# Altium schematic sheet Sheet2_V2.SchDoc(Sheet2_V2)
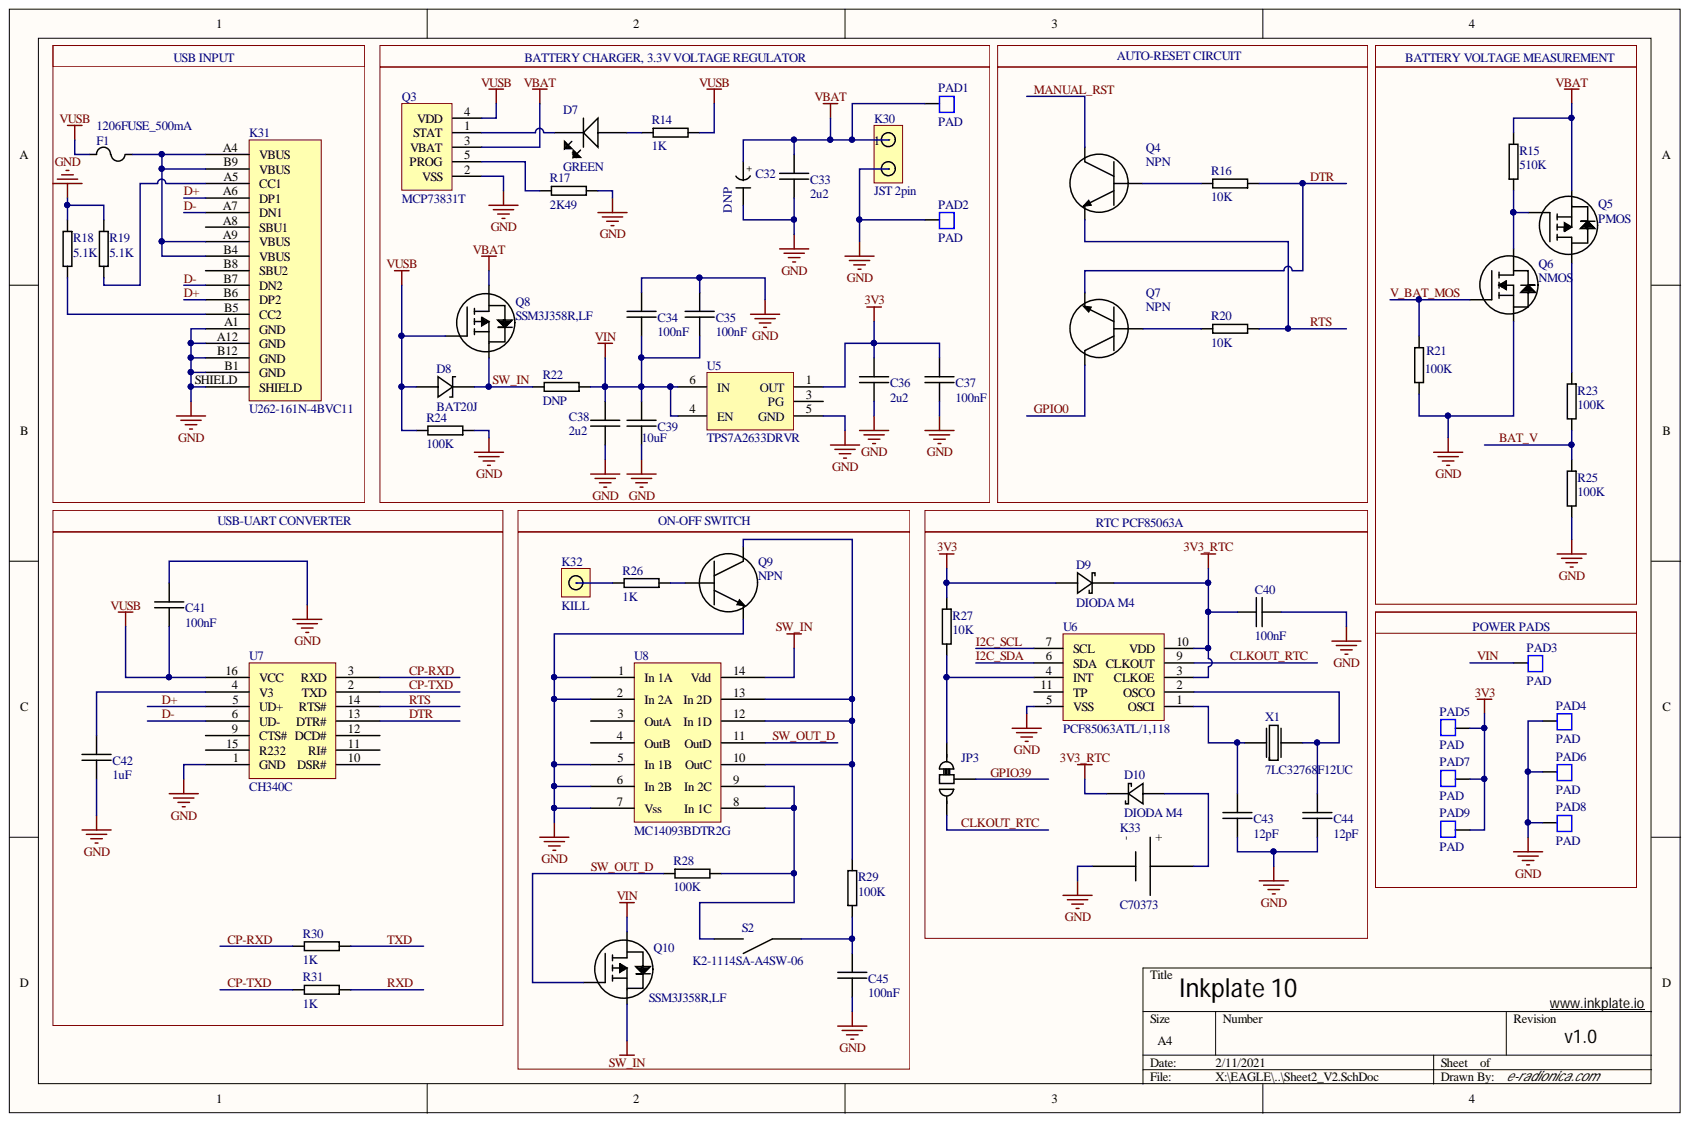
[diagram p0: Sheet2_V2.SchDoc]

## Parts
C32  DNP  [2917C]
C33  2u2  [0603C]
C34  100nF  [0603C]
C35  100nF  [0603C]
C36  2u2  [0603C]
C37  100nF  [0603C]
C38  2u2  [0603C]
C39  10uF  [1206C]
C40  100nF  [0603C]
C41  100nF  [0603C]
C42  1uF  [0603C]
C43  12pF  [0603C]
C44  12pF  [0603C]
C45  100nF  [0603C]
D7  GREEN  [0603LED]
D8  BAT20J  [SOD-323]
D9  DIODA M4  [DIODA SMA]
D10  DIODA M4  [DIODA SMA]
F1  1206FUSE_500mA  [1206FUSE]
JP3  2/3 pads connected  [SMD_JUMPER 2/3 pads]  (value not drawn)
K30  JST 2pin  [JST 2PIN SMD]
K31  U262-161N-4BVC11  [U262-161N-4BVC11]
K32  KILL  [HEADER MALE 1x1]
K33  C70373  [C70373]
PAD1  PAD  [PAD4x4]
PAD2  PAD  [PAD4x4]
PAD3  PAD  [PAD4x4]
PAD4  PAD  [PAD4x4]
PAD5  PAD  [PAD4x4]
PAD6  PAD  [PAD4x4]
PAD7  PAD  [PAD4x4]
PAD8  PAD  [PAD4x4]
PAD9  PAD  [PAD4x4]
Q3  MCP73831T  [SOT-23-5]
Q4  NPN  [SOT-23-3]
Q5  PMOS  [SOT-23-3]
Q6  NMOS  [SOT-23-3]
Q7  NPN  [SOT-23-3]
Q8  SSM3J358R,LF  [SOT-23-3]
Q9  NPN  [SOT-23-3]
Q10  SSM3J358R,LF  [SOT-23-3]
R14  1K  [0603R]
R15  510K  [0603R]
R16  10K  [0603R]
R17  2K49  [0603R]
R18  5.1K  [0603R]
R19  5.1K  [0603R]
R20  10K  [0603R]
R21  100K  [0603R]
R22  DNP  [0603R]
R23  100K  [0603R]
R24  100K  [0603R]
R25  100K  [0603R]
R26  1K  [0603R]
R27  10K  [0603R]
R28  100K  [0603R]
R29  100K  [0603R]
R30  1K  [0603R]
R31  1K  [0603R]
S2  K2-1114SA-A4SW-06  [K2-1114SA-A4SW-06]
U5  TPS7A2633DRVR  [TPS7A2633DRVR]
U6  PCF85063ATL/1,118  [DFN-10]
U7  CH340C  [SOP-16]
U8  MC14093BDTR2G  [TSSOP14_MC14093B]
X1  7LC32768F12UC  [3215Crystal]

## Nets
3V3: C36.2 C37.2 D9.1 PAD5.1 PAD7.1 PAD9.1 R27.2 U5.1(OUT)
3V3_RTC: C40.1 D9.2 D10.2 U6.3(CLKOE) U6.10(VDD)
GND: C32.2 C33.1 C34.2 C35.2 C36.1 C37.1 C38.1 C39.1 C40.2 C41.2 C42.1 C43.1 C44.1 C45.1 K30.2 K31.A1(GND) K31.A12(GND) K31.B1(GND) K31.B12(GND) K31.SHIELD K33.1 PAD2.1 PAD4.1 PAD6.1 PAD8.1 Q3.2(VSS) Q6.2 Q9.2 R17.1 R18.2 R19.2 R21.1 R24.2 R25.1 U5.5(GND) U6.5(VSS) U7.1(GND) U8.1(In_1A) U8.2(In_2A) U8.5(In_1B) U8.6(In_2B) U8.7(Vss)
VBAT: C32.1 C33.2 K30.1 PAD1.1 Q3.3(VBAT) Q5.2 Q8.3 R15.1
VUSB: C41.1 D8.1 F1.1 Q3.4(VDD) Q8.1 R14.1 R24.1 U7.16(VCC)
BAT_V: R23.1 R25.2
CLKOUT_RTC: JP3.1 U6.9(CLKOUT)
CP-RXD: R30.1 U7.3(RXD)
CP-TXD: R31.1 U7.2(TXD)
D+: K31.A6(DP1) K31.B6(DP2) U7.5(UD+)
D-: K31.A7(DN1) K31.B7(DN2) U7.6(UD-)
DTR: Q7.2 R16.2 U7.13(DTR#)
GPIO0: Q7.3
GPIO39: JP3.2
I2C_SCL: U6.7(SCL)
I2C_SDA: U6.6(SDA)
MANUAL_RST: Q4.3
RTS: Q4.2 R20.2 U7.14(RTS#)
RXD: R31.2
SW_IN: D8.2 Q8.2 Q10.2 R22.1 U8.14(Vdd)
SW_OUT_D: Q10.1 R28.2 U8.11(OutD)
TXD: R30.2
V_BAT_MOS: Q6.1 R21.2
VIN: C34.1 C35.1 C38.2 C39.2 PAD3.1 Q10.3 R22.2 U5.4(EN) U5.6(IN)
NetC42_2: C42.2 U7.4(V3)
NetC43_2: C43.2 U6.1(OSCI) X1.1
NetC44_2: C44.2 U6.2(OSCO) X1.2
NetC45_2: C45.2 R29.2 S2.1
NetD7_1: D7.1 R14.2
NetD7_2: D7.2 Q3.1(STAT)
NetD10_1: D10.1 K33.2
NetF1_2: F1.2 K31.A4(VBUS) K31.A9(VBUS) K31.B4(VBUS) K31.B9(VBUS)
NetJP3_3: JP3.3 R27.1 U6.4(INT)
NetK31_A5: K31.A5(CC1) R19.1
NetK31_B5: K31.B5(CC2) R18.1
NetK32_1: K32.1 R26.1
NetQ3_5: Q3.5(PROG) R17.2
NetQ4_1: Q4.1 R16.1
NetQ5_1: Q5.1 Q6.3 R15.2
NetQ5_3: Q5.3 R23.2
NetQ7_1: Q7.1 R20.1
NetQ9_1: Q9.1 R26.2
NetQ9_3: Q9.3 R29.1 U8.10(OutC) U8.12(In_1D) U8.13(In_2D)
NetR28_1: R28.1 S2.2 U8.8(In_1C) U8.9(In_2C)

Not connected: K31 A8(SBU1) B8(SBU2); U5 3(PG); U6 11(TP); U7 9(CTS#) 10(DSR#) 11(RI#) 12(DCD#) 15(R232); U8 3(OutA) 4(OutB)

## Library data of the parts
C32 = 2917C: Component Kind: Standard; Library Name: e-radionica.com schematics.SchLib
C33-C38 C40-C45 = 0603C: Component Kind: Standard; Library Name: e-radionica.com schematics.SchLib
C39 = 1206C: Component Kind: Standard; Library Name: e-radionica.com schematics.SchLib
D7 = 0603LED: Component Kind: Standard; Library Name: e-radionica.com schematics.SchLib
F1 = 1206FUSE: Component Kind: Standard; Library Name: e-radionica.com schematics.SchLib
JP3 = SMD_JUMPER 2/3 pads connected: Component Kind: Standard; Library Name: e-radionica.com schematics.SchLib
K31 = U262-161N-4BVC11: Inkplate; Component Kind: Standard; Library Name: e-radionica.com schematics.SchLib
K32 = HEADER 1x1: Component Kind: Standard; Library Name: e-radionica.com schematics.SchLib
K33 = C70373 battery holder: Component Kind: Standard; Library Name: e-radionica.com schematics.SchLib
Q4 Q7 Q9 = NPN SOT-23-3: Supplier Part Number 3: DNBT8105DICT-ND; Supplier Part Number 2: DNBT8105-7; Supplier Part Number 1: 621-DNBT8105-7; Supplier 3: Digi-Key; Supplier 2: Arrow; Supplier 1: Mouser; Component Kind: Standard; Library Name: e-radionica.com schematics.SchLib
Q5 Q8 Q10 = PMOS SOT-23-3: Supplier Part Number 3: DMP3120L-7; Supplier Part Number 2: 621-DMP3120L-7; Supplier Part Number 1: DMP3120L-7DI-ND; Supplier 3: Arrow; Supplier 2: Mouser; Supplier 1: Digi-Key; Component Kind: Standard; Library Name: e-radionica.com schematics.SchLib
Q6 = NMOS SOT-23-3: Supplier Part Number 3: ZXMN2A14FCT-ND; Supplier Part Number 2: ZXMN2A14FTA; Supplier Part Number 1: 522-ZXMN2A14FTA; Supplier 3: Digi-Key; Supplier 2: Arrow; Supplier 1: Mouser; Component Kind: Standard; Library Name: e-radionica.com schematics.SchLib
R14-R31 = 0603R: Component Kind: Standard; Library Name: e-radionica.com schematics.SchLib
S2 = Pushbutton K2-1114SA-A4SW-06: Inkplate; Component Kind: Standard; Library Name: e-radionica.com schematics.SchLib
U6 = PCF85063A: Component Kind: Standard; Library Name: e-radionica.com schematics.SchLib
U8 = MC14093BDTR2G: Inkplate; Component Kind: Standard; Library Name: e-radionica.com schematics.SchLib
X1 = 3215Crystal: Component Kind: Standard; Library Name: e-radionica.com schematics.SchLib
D8 = BAT20J: Supplier Part Number 3: 497-3381-1-ND; Supplier Part Number 2: BAT20JFILM; Supplier Part Number 1: 511-BAT20JFILM; Supplier 3: Digi-Key; Supplier 2: Arrow; Supplier 1: Mouser; Component Kind: Standard; Library Name: e-radionica.com schematics.SchLib
D9 D10 = DIODA M4: Component Kind: Standard; Library Name: e-radionica.com schematics.SchLib
K30 = JST 2pin: Component Kind: Standard; Library Name: e-radionica.com schematics.SchLib
PAD1-PAD9 = PAD: Component Kind: Standard; Library Name: e-radionica.com schematics.SchLib
Q3 = MCP73831T: Supplier Part Number 3: MCP73831T-2ACI/OTCT-ND; Supplier Part Number 2: MCP73831T-2ACI/OT; Supplier Part Number 1: 579-MCP73831T-2ACIOT; Supplier 3: Digi-Key; Supplier 2: Arrow; Supplier 1: Mouser; Component Kind: Standard; Library Name: e-radionica.com schematics.SchLib
U5 = TPS7A2633DRVR: Component Kind: Standard; Library Name: e-radionica.com schematics.SchLib
U7 = CH340C: Component Kind: Standard; Library Name: e-radionica.com schematics.SchLib
